# Altium schematic sheet 01-ALIM_1.SchDoc(01-ALIM_1)
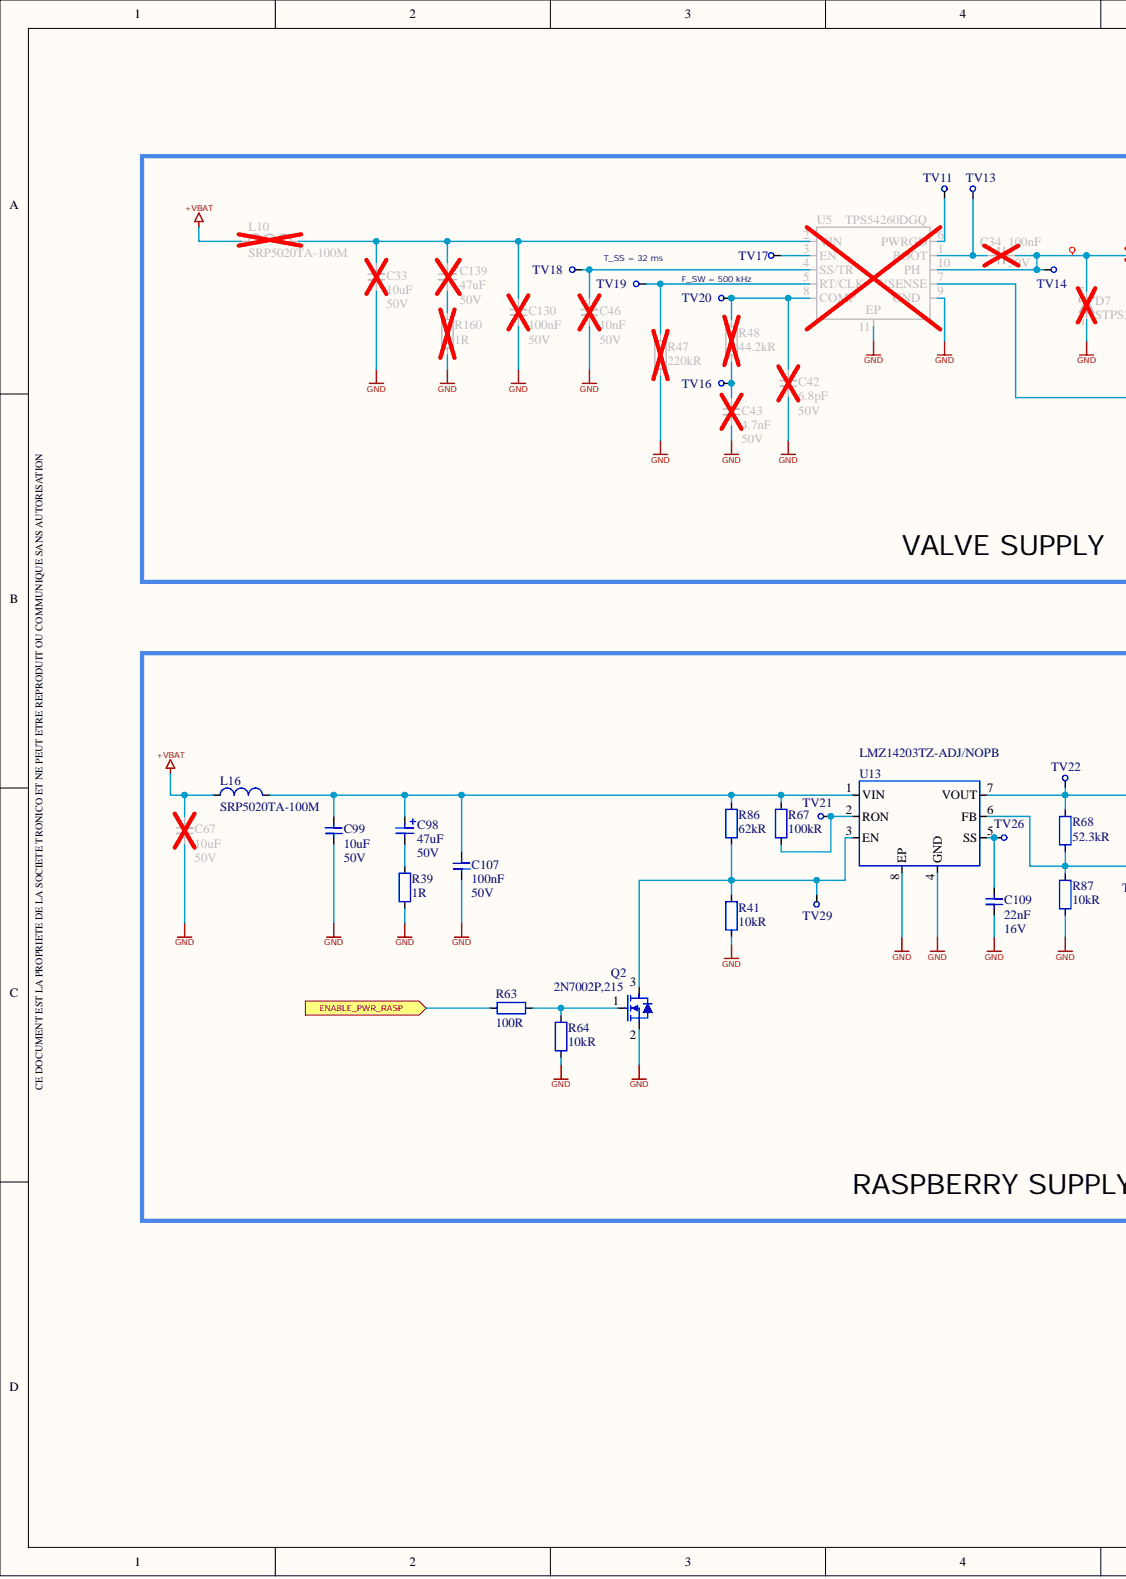
[diagram p0: 01-ALIM_1.SchDoc, left]
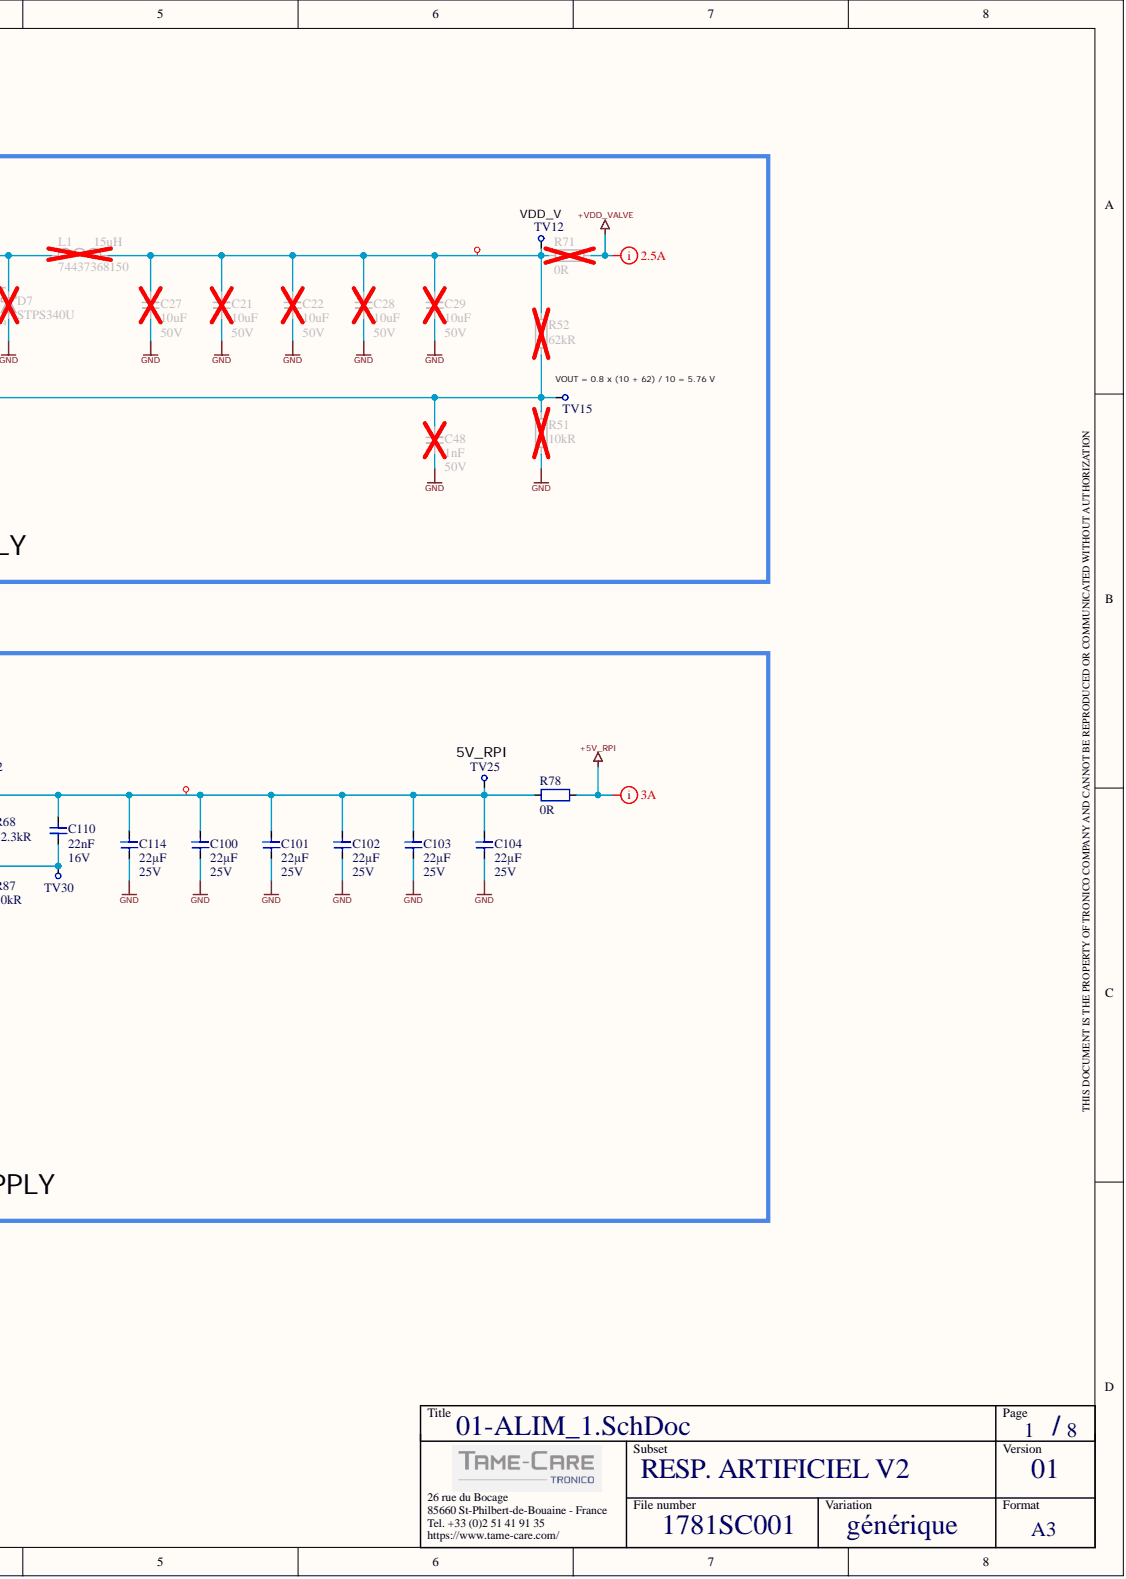
[diagram p1: 01-ALIM_1.SchDoc, right]

## Parts
C21  10uF  Parameter 1: 50V  [CAPC_1206X160_T]
C22  10uF  Parameter 1: 50V  [CAPC_1206X160_T]
C27  10uF  Parameter 1: 50V  [CAPC_1206X160_T]
C28  10uF  Parameter 1: 50V  [CAPC_1206X160_T]
C29  10uF  Parameter 1: 50V  [CAPC_1206X160_T]
C33  10uF  Parameter 1: 50V  [CAPC_1206X160_T]
C34  100nF  Parameter 1: 50V  [CAPC_0603X094_T]
C42  6.8pF  Parameter 1: 50V  [CAPC_0603X094_T]
C43  4.7nF  Parameter 1: 50V  [CAPC_0603X080_T]
C46  10nF  Parameter 1: 50V  [CAPC_0603X080_T]
C48  1nF  Parameter 1: 50V  [CAPC_0603X080_T]
C67  10uF  Parameter 1: 50V  [CAPC_1206X160_T]
C98  47uF  Parameter 1: 50V  [CAPE_D_B]
C99  10uF  Parameter 1: 50V  [CAPC_1206X160_T]
C100  22µF  Parameter 1: 25V  [CAPC_1206X160_T]
C101  22µF  Parameter 1: 25V  [CAPC_1206X160_T]
C102  22µF  Parameter 1: 25V  [CAPC_1206X160_T]
C103  22µF  Parameter 1: 25V  [CAPC_1206X160_T]
C104  22µF  Parameter 1: 25V  [CAPC_1206X160_T]
C107  100nF  Parameter 1: 50V  [CAPC_0603X094_T]
C109  22nF  Parameter 1: 16V  [CAPC_0603X080_T]
C110  22nF  Parameter 1: 16V  [CAPC_0603X080_T]
C114  22µF  Parameter 1: 25V  [CAPC_1206X160_T]
C130  100nF  Parameter 1: 50V  [CAPC_0603X094_T]
C139  47uF  Parameter 1: 50V  [CAPE_D_B]
D7  STPS340U  [DIOC_SMB_DO-214AA_T]
L1  74437368150  CHAMP5: 15uH  [INDC_WE-1040_B]
L10  SRP5020TA-100M  [INDC_SRP5020T_B]
L16  SRP5020TA-100M  [INDC_SRP5020T_B]
Q2  2N7002P,215  [SOT23_T]
R39  1R  [RESC_2512X060_T]
R41  10kR  [RESC_0603X045_T]
R47  220kR  [RESC_0603X045_T]
R48  44.2kR  [RESC_0603X045_T]
R51  10kR  [RESC_0603X045_T]
R52  62kR  [RESC_0603X045_T]
R63  100R  [RESC_0603X045_T]
R64  10kR  [RESC_0603X045_T]
R67  100kR  [RESC_0603X045_T]
R68  52.3kR  [RESC_0603X045_T]
R71  0R  [RESC_1206X055_T]
R78  0R  [RESC_1206X055_T]
R86  62kR  [RESC_0603X045_T]
R87  10kR  [RESC_0603X045_T]
R160  1R  [RESC_2512X060_T]
TV11  PT_0.4  [PT_0.4-1.0mm]  (value not drawn)  (no BOM)
TV12  PT_0.4  [PT_0.4-1.0mm]  (value not drawn)  (no BOM)
TV13  PT_0.4  [PT_0.4-1.0mm]  (value not drawn)  (no BOM)
TV14  PT_0.4  [PT_0.4-1.0mm]  (value not drawn)  (no BOM)
TV15  PT_0.4  [PT_0.4-1.0mm]  (value not drawn)  (no BOM)
TV16  PT_0.4  [PT_0.4-1.0mm]  (value not drawn)  (no BOM)
TV17  PT_0.4  [PT_0.4-1.0mm]  (value not drawn)  (no BOM)
TV18  PT_0.4  [PT_0.4-1.0mm]  (value not drawn)  (no BOM)
TV19  PT_0.4  [PT_0.4-1.0mm]  (value not drawn)  (no BOM)
TV20  PT_0.4  [PT_0.4-1.0mm]  (value not drawn)  (no BOM)
TV21  PT_0.4  [PT_0.4-1.0mm]  (value not drawn)  (no BOM)
TV22  PT_0.4  [PT_0.4-1.0mm]  (value not drawn)  (no BOM)
TV25  PT_0.4  [PT_0.4-1.0mm]  (value not drawn)  (no BOM)
TV26  PT_0.4  [PT_0.4-1.0mm]  (value not drawn)  (no BOM)
TV29  PT_0.4  [PT_0.4-1.0mm]  (value not drawn)  (no BOM)
TV30  PT_0.4  [PT_0.4-1.0mm]  (value not drawn)  (no BOM)
U5  TPS54260DGQ  [VSSOP10-EP_0.5_3.0X3.0X1.1_B]
U13  LMZ14203TZ-ADJ/NOPB  [TO-PMOD-7_10.16X9.85X4.57_B]

## Ports
ENABLE_PWR_RASP

## Nets
+5V_RPI: R78.1
+VBAT: C67.2 L10.1 L16.1
+VDD_VALVE: R71.1
GND: C21.1 C22.1 C27.1 C28.1 C29.1 C33.1 C42.1 C43.1 C46.1 C48.1 C67.1 C99.1 C100.1 C101.1 C102.1 C103.1 C104.1 C107.1 C109.1 C114.2 C130.1 D7.2 Q2.2 R39.2 R41.2 R47.2 R51.2 R64.2 R87.2 R160.2 U5.9(GND) U5.11(EP) U13.4(GND) U13.8(EP)
ENABLE_PWR_RASP: R63.2 ; port ENABLE_PWR_RASP
NetC21_2: C21.2 C22.2 C27.2 C28.2 C29.2 L1.2 R52.1 R71.2 TV12.1
NetC33_2: C33.2 C130.2 C139.1 L10.2 U5.2(VIN)
NetC34_1: C34.1 TV13.1 U5.1(BOOT)
NetC34_2: C34.2 D7.1 L1.1 TV14.1 U5.10(PH)
NetC42_2: C42.2 R48.1 TV20.1 U5.8(COMP)
NetC43_2: C43.2 R48.2 TV16.1
NetC46_2: C46.2 TV18.1 U5.4(SS/TR)
NetC48_2: C48.2 R51.1 R52.2 TV15.1 U5.7(VSENSE)
NetC98_1: C98.1 C99.2 C107.2 L16.2 R67.1 R86.1 U13.1(VIN)
NetC98_2: C98.2 R39.1
NetC100_2: C100.2 C101.2 C102.2 C103.2 C104.2 C110.1 C114.1 R68.1 R78.2 TV22.1 TV25.1 U13.7(VOUT)
NetC109_2: C109.2 TV26.1 U13.5(SS)
NetC110_2: C110.2 R68.2 R87.1 TV30.1 U13.6(FB)
NetC139_2: C139.2 R160.1
NetQ2_1: Q2.1 R63.1 R64.1
NetQ2_3: Q2.3 R41.1 R86.2 TV29.1 U13.3(EN)
NetR47_1: R47.1 TV19.1 U5.5(RT/CLK)
NetR67_2: R67.2 TV21.1 U13.2(RON)
NetTV11_1: TV11.1 U5.6(PWRGD)
NetTV17_1: TV17.1 U5.3(EN)

## Library data of the parts
C21 C22 C27-C29 C33 C67 C99 = 23U00488: COND_CERAM CMS 1206 X5R 10uF 50V 10%; REV_NOTE: Migration vers t_bt; REV_AUTHOR: lclavier; REF_FAB: GRM31CR61H106KA12L; LEVEL: 1; FAMILLE: CAPACITIFS; FAB: MURATA; Database Table Name: v_bt_all; CHAMP9: -55°C/+85°C; CHAMP2: 1206; SS_FAMILLE: COND_CERAM; Simulation: CAP; Signal Integrity: Cap; REV_DATE: 14/04/2020 16:23:14; ID_RnD: 23U00488; HelpURL: http://bt_rnd/ds/02_capacitif/murata/GRM31CR61H106KA12L.pdf; ComponentLink1URL: https://www.murata.com/en-us/products/productdetail?partno=GRM31CR61H106KA12%23; Component Kind: Standard; CHAMP7: 10%; CHAMP6: 50V; CHAMP5: 10uF; CHAMP3: X5R; CHAMP1: CMS; ACTIF: ACTIF; Library Name: SVNDatabase_BaseTechnique.SVNDbLib
C34 C107 C130 = 23U00036: COND_CERAM CMS 0603 X7R 100nF 50V 10%; REV_NOTE: Maj Champs Techniques; REV_AUTHOR: nlelievre; REF_FAB: 06035C104KAT2A; LEVEL: 1; FAMILLE: CAPACITIFS; FAB: AVX; Database Table Name: v_bt_all; CHAMP9: -55°C/+125°C; CHAMP2: 0603; SS_FAMILLE: COND_CERAM; Simulation: CAP; Signal Integrity: Cap; REV_DATE: 16/10/2019 13:20:57; Library Name: SVNDatabase_BaseTechnique.SVNDbLib; ID_RnD: 23U00036; HelpURL: http://Bt_rnd/ds/02_capacitif/AVX/AVX_cx7r.pdf; ComponentLink2Description: Manufacturer Website; ComponentLink1Description: Manufacturer Datasheet; Component Kind: Standard; CHAMP7: 10%; CHAMP6: 50V; CHAMP5: 100nF; CHAMP3: X7R; CHAMP1: CMS; ACTIF: ACTIF
C42 = 23U00055: COND_CERAM CMS 0603 C0G 6.8pF 50V 0.25pF; REV_NOTE: Maj Champs Techniques; REV_AUTHOR: nlelievre; REF_FAB: C0603C689C5GACTU; LEVEL: 1; FAMILLE: CAPACITIFS; FAB: KEMET; Database Table Name: v_bt_all; CHAMP9: -55°C/+125°C; CHAMP2: 0603; SS_FAMILLE: COND_CERAM; Simulation: CAP; Signal Integrity: Cap; REV_DATE: 16/10/2019 13:26:48; Library Name: SVNDatabase_BaseTechnique.SVNDbLib; ID_RnD: 23U00055; HelpURL: http://bt_rnd/ds/02_capacitif/KEMET/KEMET_KEM_C1003_C0G_SMD.pdf; ComponentLink2Description: None; ComponentLink1Description: Manufacturer Datasheet; Component Kind: Standard; CHAMP7: 0.25pF; CHAMP6: 50V; CHAMP5: 6.8pF; CHAMP3: C0G; CHAMP1: CMS; ACTIF: ACTIF
C43 = 23U00490: COND_CERAM CMS 0603 X7R 4.7nF 50V 5%; REV_NOTE: Migration vers t_bt; REV_AUTHOR: lclavier; REF_FAB: C0603C472J5RACTU; LEVEL: 1; FAMILLE: CAPACITIFS; FAB: KEMET; Database Table Name: v_bt_all; CHAMP9: -55°C/+125°C; CHAMP2: 0603; SS_FAMILLE: COND_CERAM; Simulation: CAP; Signal Integrity: Cap; REV_DATE: 20/04/2020 18:40:48; ID_RnD: 23U00490; HelpURL: http://BT_RND/ds/02_capacitif/KEMET/KEMET_KEM_C1002_X7R_SMD.pdf; ComponentLink2Description: Manufacturer Website; ComponentLink1Description: Manufacturer Datasheet; Component Kind: Standard; CHAMP7: 5%; CHAMP6: 50V; CHAMP5: 4.7nF; CHAMP3: X7R; CHAMP1: CMS; ACTIF: ACTIF; Library Name: SVNDatabase_BaseTechnique.SVNDbLib
C46 = 23U00104: COND_CERAM CMS 0603 X7R 10nF 50V 10%; REV_NOTE: Maj Champs Techniques; REV_AUTHOR: nlelievre; REF_FAB: C0603C103K5RACTU; LEVEL: 1; FAMILLE: CAPACITIFS; FAB: KEMET; Database Table Name: v_bt_all; CHAMP9: -55°C/+125°C; CHAMP2: 0603; SS_FAMILLE: COND_CERAM; Simulation: CAP; Signal Integrity: Cap; REV_DATE: 16/10/2019 13:28:44; Library Name: SVNDatabase_BaseTechnique.SVNDbLib; ID_RnD: 23U00104; HelpURL: http://bt_rnd/ds/02_capacitif/KEMET/KEMET_KEM_C1002_X7R_SMD.pdf; ComponentLink2Description: Manufacturer Website; ComponentLink1Description: Manufacturer Datasheet; Component Kind: Standard; CHAMP7: 10%; CHAMP6: 50V; CHAMP5: 10nF; CHAMP3: X7R; CHAMP1: CMS; ACTIF: ACTIF
C48 = 23U00183: COND_CERAM CMS 0603 X7R 1nF 50V 10%; REV_NOTE: Maj Champs Techniques; REV_AUTHOR: nlelievre; REF_FAB: GRM188R71H102KA01D; LEVEL: 1; FAMILLE: CAPACITIFS; FAB: MURATA; Database Table Name: v_bt_all; CHAMP9: -55°C/+125°C; CHAMP2: 0603; SS_FAMILLE: COND_CERAM; Simulation: CAP; Signal Integrity: Cap; REV_DATE: 16/10/2019 13:30:44; Library Name: SVNDatabase_BaseTechnique.SVNDbLib; ID_RnD: 23U00183; HelpURL: http://bt_rnd/ds/02_capacitif/murata/murata_c02e.pdf; ComponentLink2Description: Manufacturer Website; ComponentLink1URL: http://www.murata.com/~/media/webrenewal/support/library/catalog/products/capacitor/mlcc/c02e.pdf; ComponentLink1Description: Manufacturer Datasheet; Component Kind: Standard; CHAMP7: 10%; CHAMP6: 50V; CHAMP5: 1nF; CHAMP3: X7R; CHAMP1: CMS; ACTIF: ACTIF
C98 C139 = 21U00041: COND_ALUM CMS D:6.3mm 47uF 50V 20% 680mR; REV_NOTE: Migration vers t_bt; REV_AUTHOR: lclavier; REF_FAB: EEEFT1H470AP; QUALIF: None; LEVEL: 1; FAMILLE: CAPACITIFS; FAB: PANASONIC; Database Table Name: v_bt_all; CHAMP9: -55°C/+105°C; SS_FAMILLE: COND_ALUM; Simulation: CAP; Signal Integrity: Cap Pol; REV_DATE: 14/04/2020 16:15:27; ID_RnD: 21U00041; HelpURL: http://bt_rnd/ds/02_capacitif/Panasonic/EEEFT1H470AP.pdf; ComponentLink1URL: https://industrial.panasonic.com/ww/products/capacitors/aluminum-capacitors/aluminum-cap-smd/ft-v-high-temp-reflow/EEEFT1H470AP; Component Kind: Standard; CHAMP8: 680mR; CHAMP7: 20%; CHAMP6: 50V; CHAMP5: 47uF; CHAMP3: D:6.3mm; CHAMP1: CMS; ACTIF: ACTIF; Library Name: SVNDatabase_BaseTechnique.SVNDbLib
C100-C104 C114 = 23U00492: COND_CERAM CMS 1206 X5R 22µF 25V 20%; REV_NOTE: Migration vers t_bt; REV_AUTHOR: lclavier; REF_FAB: GRT31CR61E226ME01L; LEVEL: 1; FAMILLE: CAPACITIFS; FAB: MURATA; Database Table Name: v_bt_all; CHAMP9: -55°C/+85°C; CHAMP2: 1206; SS_FAMILLE: COND_CERAM; Simulation: CAP; Signal Integrity: Cap; REV_DATE: 02/05/2020 20:06:00; ID_RnD: 23U00492; HelpURL: http://bt_rnd/ds/02_capacitif/murata/GRT31CR61E226ME01-01.pdf; ComponentLink2Description: Manufacturer Website; ComponentLink1Description: Manufacturer Datasheet; Component Kind: Standard; CHAMP7: 20%; CHAMP6: 25V; CHAMP5: 22µF; CHAMP3: X5R; CHAMP1: CMS; ACTIF: ACTIF; Library Name: SVNDatabase_BaseTechnique.SVNDbLib
C109 C110 = 23U00059: COND_CERAM CMS 0603 X7R 22nF 16V 10%; REV_NOTE: Maj Champs Techniques; REV_AUTHOR: nlelievre; REF_FAB: C0603C223K4RACTU; LEVEL: 1; FAMILLE: CAPACITIFS; FAB: KEMET; Database Table Name: v_bt_all; CHAMP9: -55°C/+125°C; CHAMP2: 0603; SS_FAMILLE: COND_CERAM; Simulation: CAP; Signal Integrity: Cap; REV_DATE: 16/10/2019 13:26:48; Library Name: SVNDatabase_BaseTechnique.SVNDbLib; ID_RnD: 23U00059; HelpURL: http://bt_rnd/ds/02_capacitif/KEMET/KEMET_KEM_C1002_X7R_SMD.pdf; ComponentLink2Description: Manufacturer Website; ComponentLink1Description: Manufacturer Datasheet; Component Kind: Standard; CHAMP7: 10%; CHAMP6: 16V; CHAMP5: 22nF; CHAMP3: X7R; CHAMP1: CMS; ACTIF: ACTIF
D7 = 39U00073: DIODE_SCHOTTKY CMS SMB 40V 3A SINGLE; REV_NOTE: Migration vers t_bt; REV_AUTHOR: lclavier; LEVEL: 1; FAMILLE: DIODES; FAB: ST MICROELECTRONICS; Database Table Name: v_bt_all; CHAMP10: -65°C/+150°C; CHAMP9: -40°C/+105°C; SS_FAMILLE: DIODE_SCHOTTKY; Simulation: SKYDIODE; Signal Integrity: D Schottky; REV_DATE: 17/04/2020 20:16:17; REF_FAB: STPS340U; ID_RnD: 39U00073; HelpURL: http://bt_rnd/ds/03_diode/ST/stps340.pdf; ComponentLink2Description: Manufacturer Website; ComponentLink1Description: Manufacturer Datasheet; Component Kind: Standard; CHAMP8: SINGLE; CHAMP6: 3A; CHAMP5: 40V; CHAMP2: SMB; CHAMP1: CMS; ACTIF: ACTIF; Library Name: SVNDatabase_BaseTechnique.SVNDbLib
L1 = 42U00158: SELF CMS 1040 15uH 20% 8.5A; REV_NOTE: MaJ Champs Techniques; REV_AUTHOR: llannuzel; QUALIF: None; LEVEL: 1; FAMILLE: INDUCTIFS; FAB: WURTH ELEKTRONIK; Database Table Name: v_bt_all; CHAMP9: -40°C/+125°C; CHAMP2: 1040; SS_FAMILLE: SELF; Simulation: INDUCTOR; Signal Integrity: Inductor; REV_DATE: 03/05/2020 14:35:47; REF_FAB: 74437368150; Library Name: SVNDatabase_BaseTechnique.SVNDbLib; ID_RnD: 42U00158; HelpURL: http://bt_rnd/ds/04_inductif/WE/74437368150.pdf; ComponentLink2Description: Manufacturer Website; ComponentLink1Description: Manufacturer Datasheet; Component Kind: Standard; CHAMP7: 8.5A; CHAMP6: 20%; CHAMP1: CMS; ACTIF: ACTIF
L10 L16 = 42U00140: SELF CMS 5.2x5.7x1.8mm 10µH 20% 3.4A; REV_NOTE: MaJ Champs Librairies; REV_AUTHOR: lclavier; LEVEL: 1; FAMILLE: INDUCTIFS; FAB: BOURNS; Database Table Name: v_bt_all; CHAMP10: -40°C/+150°C; SS_FAMILLE: SELF; Simulation: INDUCTOR; Signal Integrity: Inductor; REV_DATE: 21/10/2019 17:00:03; REF_FAB: SRP5020TA-100M; ID_RnD: 42U00140; HelpURL: http://bt_rnd/ds/04_inductif/BOURNS/srp5020ta.pdf; ComponentLink2Description: Manufacturer Website; ComponentLink1Description: Manufacturer Datasheet; Component Kind: Standard; CHAMP9: -40°C/+150°C; CHAMP7: 3.4A; CHAMP6: 20%; CHAMP5: 10µH; CHAMP2: 5.2x5.7x1.8mm; CHAMP1: CMS; ACTIF: ACTIF; Library Name: SVNDatabase_BaseTechnique.SVNDbLib
Q2 = 92U00012: TRANSISTOR_MOS CMS SOT23 CANAL N 60V 0.36A -55°C/+150°C; Valide / Non Valide: Ok; REV_NOTE: Maj Library Ref; REV_AUTHOR: jcaille; LEVEL: 1; FAMILLE: TRANSISTORS; FAB: NXP; Database Table Name: v_bt_all; CHAMP10: -65°C/+150°C; CHAMP5: CANAL N; SS_FAMILLE: TRANSISTOR_MOS; REV_DATE: 22/01/2020 14:26:53; REF_FAB: 2N7002P,215; ID_RnD: 92U00012; HelpURL: http://bt_rnd/ds/09_transistor/NXP/NXP_2N7002P.pdf; ComponentLink2Description: Manufacturer Website; ComponentLink1Description: Manufacturer Datasheet; Component Kind: Standard; CHAMP9: -55°C/+150°C; CHAMP7: 0.36A; CHAMP6: 60V; CHAMP2: SOT23; CHAMP1: CMS; ACTIF: ACTIF; Library Name: SVNDatabase_BaseTechnique.SVNDbLib
R39 R160 = 11U01009: REST CMS 2512 100PPM 1R 1.5W 1% 500V; Valide / Non Valide: OK; REV_NOTE: MaJ Champs Techniques; REV_AUTHOR: llannuzel; REF_FAB: CRCW25121R00FKEGHP; QUALIF: None; LEVEL: 1; FAMILLE: RESISTIFS; FAB: VISHAY; Database Table Name: v_bt_all; CHAMP9: -55°C/+155°C; CHAMP2: 2512; SS_FAMILLE: REST; Simulation: RESISTOR; Signal Integrity: Res; REV_DATE: 16/04/2020 13:00:07; Library Name: SVNDatabase_BaseTechnique.SVNDbLib; ID_RnD: 11U01009; HelpURL: file://bt_rnd/ds/01_resistif/Vishay/CRCW25121R00FKEGHP.pdf; ComponentLink1URL: https://octopart.com/crcw25121r00fkeghp-vishay-44382594?r=sp; Component Kind: Standard; CHAMP8: 500V; CHAMP7: 1%; CHAMP6: 1.5W; CHAMP5: 1R; CHAMP3: 100PPM; CHAMP1: CMS; ACTIF: ACTIF
R41 R51 R64 R87 = 11U00108: REST CMS 0603 100PPM 10kR 1/10W 1% -55°C/+155°C; Valide / Non Valide: OK; REV_NOTE: MaJ description; REV_AUTHOR: jrenvoise; REF_FAB: RC0603FR-0710KL; LEVEL: 1; FAMILLE: RESISTIFS; FAB: YAGEO; Database Table Name: v_bt_all; CHAMP2: 0603; SS_FAMILLE: REST; Simulation: RESISTOR; Signal Integrity: Res; REV_DATE: 12/09/2018 10:54:26; ID_RnD: 11U00108; HelpURL: http://bt_rnd/ds/01_resistif/yageo/RC-L_Series.pdf; ComponentLink2Description: Manufacturer Website; ComponentLink1Description: Manufacturer Datasheet; Component Kind: Standard; CHAMP9: -55°C/+155°C; CHAMP7: 1%; CHAMP6: 1/10W; CHAMP5: 10kR; CHAMP3: 100PPM; CHAMP1: CMS; ACTIF: ACTIF; Library Name: SVNDatabase_BaseTechnique.SVNDbLib
R47 = 11U01019: REST CMS 0603 100PPM 220kR 1/10W 1% 75V; Valide / Non Valide: OK; REV_NOTE: Migration vers t_bt; REV_AUTHOR: lclavier; REF_FAB: RC0603FR-07220KL; LEVEL: 1; FAMILLE: RESISTIFS; FAB: YAGEO; Database Table Name: v_bt_all; CHAMP9: -55°C/+155°C; CHAMP2: 0603; SS_FAMILLE: REST; Simulation: RESISTOR; Signal Integrity: Res; REV_DATE: 21/04/2020 09:23:29; ID_RnD: 11U01019; HelpURL: http://bt_rnd/ds/01_resistif/yageo/RC-L_Series.pdf; ComponentLink2Description: Manufacturer Website; ComponentLink1Description: Manufacturer Datasheet; Component Kind: Standard; CHAMP8: 75V; CHAMP7: 1%; CHAMP6: 1/10W; CHAMP5: 220kR; CHAMP3: 100PPM; CHAMP1: CMS; ACTIF: ACTIF; Library Name: SVNDatabase_BaseTechnique.SVNDbLib
R48 = 11U01013: REST CMS 0603 100PPM 44.2kR 1/10W 1% 75V; Valide / Non Valide: OK; REV_NOTE: MaJ Champs Techniques; REV_AUTHOR: jcaille; REF_FAB: CRCW060344K2FKEA; LEVEL: 1; FAMILLE: RESISTIFS; FAB: VISHAY; Database Table Name: v_bt_all; CHAMP9: -55°C/+155°C; CHAMP2: 0603; SS_FAMILLE: REST; Simulation: RESISTOR; Signal Integrity: Res; REV_DATE: 17/04/2020 12:14:56; Library Name: SVNDatabase_BaseTechnique.SVNDbLib; ID_RnD: 11U01013; HelpURL: http://bt_rnd/ds/01_resistif/Vishay/dcrcwe3.pdf; ComponentLink2Description: Manufacturer Website; ComponentLink1Description: Manufacturer Datasheet; Component Kind: Standard; CHAMP8: 75V; CHAMP7: 1%; CHAMP6: 1/10W; CHAMP5: 44.2kR; CHAMP3: 100PPM; CHAMP1: CMS; ACTIF: ACTIF
R52 R86 = 11U01010: REST CMS 0603 100PPM 62kR 1/10W 1% 75V; Valide / Non Valide: OK; REV_NOTE: Migration vers t_bt; REV_AUTHOR: jcaille; REF_FAB: CRCW060362K0FKEA; LEVEL: 1; FAMILLE: RESISTIFS; FAB: VISHAY; Database Table Name: v_bt_all; CHAMP9: -55°C/+155°C; CHAMP2: 0603; SS_FAMILLE: REST; Simulation: RESISTOR; Signal Integrity: Res; REV_DATE: 17/04/2020 11:05:23; ID_RnD: 11U01010; HelpURL: http://bt_rnd/ds/01_resistif/Vishay/dcrcwe3.pdf; ComponentLink2Description: Manufacturer Website; ComponentLink1Description: Manufacturer Datasheet; Component Kind: Standard; CHAMP8: 75V; CHAMP7: 1%; CHAMP6: 1/10W; CHAMP5: 62kR; CHAMP3: 100PPM; CHAMP1: CMS; ACTIF: ACTIF; Library Name: SVNDatabase_BaseTechnique.SVNDbLib
R63 = 11U00071: REST CMS 0603 100PPM 100R 1/10W 1% -55°C/+155°C; Valide / Non Valide: OK; REV_NOTE: MaJ description; REV_AUTHOR: jrenvoise; REF_FAB: RC0603FR-07100RL; LEVEL: 1; FAMILLE: RESISTIFS; FAB: YAGEO; Database Table Name: v_bt_all; CHAMP2: 0603; SS_FAMILLE: REST; Simulation: RESISTOR; Signal Integrity: Res; REV_DATE: 12/09/2018 10:54:26; ID_RnD: 11U00071; HelpURL: http://bt_rnd/ds/01_resistif/yageo/RC-L_Series.pdf; ComponentLink2Description: Manufacturer Website; ComponentLink1Description: Manufacturer Datasheet; Component Kind: Standard; CHAMP9: -55°C/+155°C; CHAMP7: 1%; CHAMP6: 1/10W; CHAMP5: 100R; CHAMP3: 100PPM; CHAMP1: CMS; ACTIF: ACTIF; Library Name: SVNDatabase_BaseTechnique.SVNDbLib
R67 = 11U00111: REST CMS 0603 100PPM 100kR 1/10W 1% -55°C/+155°C; Valide / Non Valide: OK; REV_NOTE: MaJ description; REV_AUTHOR: jrenvoise; REF_FAB: RC0603FR-07100KL; LEVEL: 1; FAMILLE: RESISTIFS; FAB: YAGEO; Database Table Name: v_bt_all; CHAMP2: 0603; SS_FAMILLE: REST; Simulation: RESISTOR; Signal Integrity: Res; REV_DATE: 12/09/2018 10:54:26; ID_RnD: 11U00111; HelpURL: http://bt_rnd/ds/01_resistif/yageo/RC-L_Series.pdf; ComponentLink2Description: Manufacturer Website; ComponentLink1Description: Manufacturer Datasheet; Component Kind: Standard; CHAMP9: -55°C/+155°C; CHAMP7: 1%; CHAMP6: 1/10W; CHAMP5: 100kR; CHAMP3: 100PPM; CHAMP1: CMS; ACTIF: ACTIF; Library Name: SVNDatabase_BaseTechnique.SVNDbLib
R68 = 11U01017: REST CMS 0603 100PPM 52.3kR 1/10W 1% 75V; Valide / Non Valide: OK; REV_NOTE: Migration vers t_bt; REV_AUTHOR: lclavier; REF_FAB: RC0603FR-0752K3L; LEVEL: 1; FAMILLE: RESISTIFS; FAB: YAGEO; Database Table Name: v_bt_all; CHAMP9: -55°C/+155°C; CHAMP2: 0603; SS_FAMILLE: REST; Simulation: RESISTOR; Signal Integrity: Res; REV_DATE: 20/04/2020 18:36:47; ID_RnD: 11U01017; HelpURL: http://bt_rnd/ds/01_resistif/yageo/RC-L_Series.pdf; ComponentLink2Description: Manufacturer Website; ComponentLink1Description: Manufacturer Datasheet; Component Kind: Standard; CHAMP8: 75V; CHAMP7: 1%; CHAMP6: 1/10W; CHAMP5: 52.3kR; CHAMP3: 100PPM; CHAMP1: CMS; ACTIF: ACTIF; Library Name: SVNDatabase_BaseTechnique.SVNDbLib
R71 R78 = 11U00878: REST CMS 1206 0R 1/4W 20mR 3.5A; Valide / Non Valide: OK; REV_NOTE: Migration vers t_bt; REV_AUTHOR: jcaille; REF_FAB: RCA12060000ZSEA; LEVEL: 1; FAMILLE: RESISTIFS; FAB: VISHAY; Database Table Name: v_bt_all; CHAMP9: -55°C/+155°C; CHAMP2: 1206; SS_FAMILLE: REST; Simulation: RESISTOR; Signal Integrity: Res; REV_DATE: 25/11/2019 10:49:44; ID_RnD: 11U00878; HelpURL: http://bt_rnd/ds/01_resistif/Vishay/RCAE3.pdf; ComponentLink2Description: Manufacturer Website; ComponentLink1Description: Manufacturer Datasheet; Component Kind: Standard; CHAMP8: 3.5A; CHAMP7: 20mR; CHAMP6: 1/4W; CHAMP5: 0R; CHAMP1: CMS; ACTIF: ACTIF; Library Name: SVNDatabase_BaseTechnique.SVNDbLib
TV11-TV22 TV25 TV26 TV29 TV30 = H6U00006: SS_FAMILLE: POINT_TEST; REV_NOTE: Migration vers t_bt; REV_AUTHOR: llannuzel; LEVEL: 1; FAMILLE: DIVERS; FAB: TRONICO; Database Table Name: v_bt_all; CHAMP1: PASTILLE; REV_DATE: 01/05/2020 18:33:20; REF_FAB: PT_0.4; ID_RnD: H6U00006; HelpURL: http://bt_rnd/ds/; ComponentLink2Description: Manufacturer Website; ComponentLink1Description: Manufacturer Datasheet; ACTIF: ACTIF; Library Name: SVNDatabase_BaseTechnique.SVNDbLib
U5 = 62U00131: CI_REGUL CMS VSSOP10 DEC +3.5V/+60V; +8V/+65V; REV_NOTE: Migration vers t_bt; REV_AUTHOR: lclavier; LEVEL: 1; FAMILLE: CIRCUITS_INTEGRES; FAB: TEXAS INSTRUMENTS; Database Table Name: v_bt_all; CHAMP9: -40°C/+125°C; SS_FAMILLE: CI_REGUL; REV_DATE: 20/04/2020 18:11:59; REF_FAB: TPS54260DGQ; ID_RnD: 62U00131; HelpURL: http://bt_rnd/ds/06_ci/TI/tps54260.pdf; ComponentLink2Description: Manufacturer Website; ComponentLink1Description: Manufacturer Datasheet; Component Kind: Standard; CHAMP6: +3.5V/+60V; +8V/+65V; CHAMP5: DEC; CHAMP2: VSSOP10; CHAMP1: CMS; ACTIF: ACTIF; Library Name: SVNDatabase_BaseTechnique.SVNDbLib
U13 = 51U00068: ALIM DC/DC CMS 18W +6V/+42V +0.8V/+6V +3A 90%; REV_NOTE: Migration vers t_bt; REV_AUTHOR: lclavier; LEVEL: 1; FAMILLE: ALIMENTATIONS; FAB: TEXAS INSTRUMENTS; Database Table Name: v_bt_all; CHAMP10: -65°C/+150°C; CHAMP9: -40°C/+125°C; SS_FAMILLE: ALIM; REV_DATE: 27/04/2020 15:30:07; REF_FAB: LMZ14203TZ-ADJ/NOPB; ID_RnD: 51U00068; HelpURL: http://bt_rnd/ds/05_alimentation/TI/LMZ14203.pdf; ComponentLink2Description: Manufacturer Website; ComponentLink1Description: Manufacturer Datasheet; Component Kind: Standard; CHAMP8: 90%; CHAMP7: +3A; CHAMP6: +0.8V/+6V; CHAMP5: +6V/+42V; CHAMP4: 18W; CHAMP2: CMS; CHAMP1: DC/DC; ACTIF: ACTIF; Library Name: SVNDatabase_BaseTechnique.SVNDbLib
